# Altium schematic sheet POWER.SchDoc
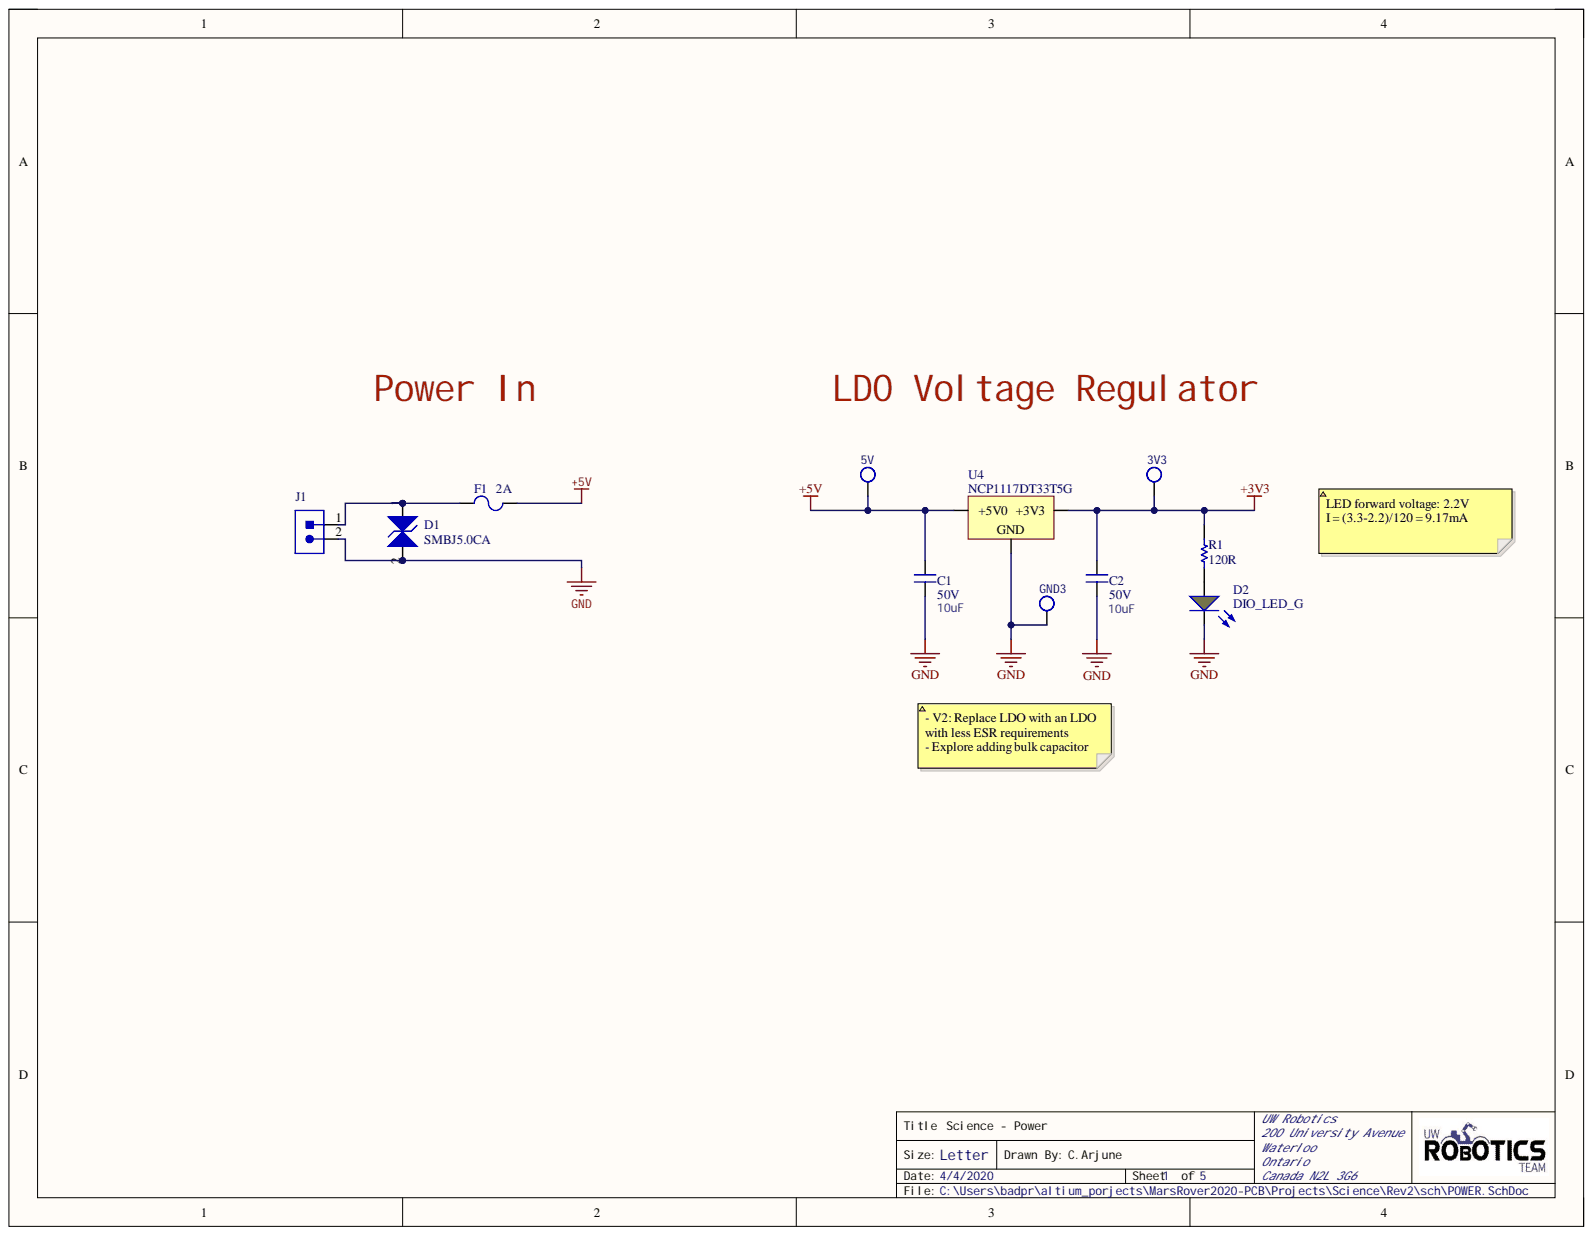
[diagram p0: POWER.SchDoc]

## Parts
3V3  TESTPOINT_LOOP  [TESTPOINT_LOOP]  (value not drawn)
5V  TESTPOINT_LOOP  [TESTPOINT_LOOP]  (value not drawn)
C1  10uF  Voltage Rating: 50V  [CAPC1206(3216)]
C2  10uF  Voltage Rating: 50V  [CAPC1206(3216)]
D1  Manufacturer Part Number 1: SMBJ5.0CA  [TVS_DIODE_BIDIRECTIONAL]  (hidden comment: TVS_DIODE_BIDIRECTIONAL)
D2  DIO_LED_G  [LED_0805_LINE]
F1  Current Rating: 2A  [FUSE_0603]  (hidden comment: FUSE_2A_0603)
GND3  TESTPOINT_LOOP  [TESTPOINT_LOOP]  (value not drawn)
J1  CON_2M_SM4  [JST-B2B-SM4-TB]  (value not drawn)
R1  value 120R  [RESC0603(1608)_N]  (hidden comment: RES_120R_0603)
U4  MPN: NCP1117DT33T5G  [VOLTAGE_REGULATOR]  (hidden comment: 5V0_3V3_LDO)

## Nets
+3V3: 3V3.1 C2.1 R1.2 U4.2
+5V: 5V.1 C1.1 F1.2 U4.3
GND: C1.2 C2.2 D1.2 D2.2 GND3.1 J1.2 U4.1
NetD1_1: D1.1 F1.1 J1.1
NetD2_1: D2.1 R1.1

## Library data of the parts
3V3 5V GND3 = TESTPOINT_LOOP: Loop testpoint through-hole pad; Manufacturer: Keystone Electronics; Manufacturer Part Number 1: 5002; Component Kind: Standard; Supplier 1: Digi-Key; Supplier Part Number 1: 36-5002-ND; Library Name: UWRT_Schematic_Library_2020.SchLib
C1 C2 = CAP_10uF_50V_1206: CAP CER 10UF 50V X5R 1206; Manufacturer: Murata; MPN: GRT31CR61H106KE01L; Component Kind: Standard; Dimensions: 0.126" L x 0.063" W (3.20mm x 1.6mm); Package: 1206 (3216 Metric); Signal Integrity: Cap; Simulation: CAP; Supplier 1: Digi-Key; Supplier Part Number 1: 490-12456-1-ND; Value: 10uF; Library Name: UWRT_Schematic_Library_2020.SchLib
D1 = TVS_DIODE_BIDIRECTIONAL: TVS DIODE 5V 9.2V DO214AA; Manufacturer 1: Littelfuse; Breakdown Voltage: 6.4V; ESD Protection: Yes; Max Breakdown Voltage: 7V; Max Surge Current: 65.3A; Peak Pulse Power: 600W; Clamping Voltage: 9.2V; Component Kind: Standard; ComponentLink1Description: Datasheet; ComponentLink1URL: https://www.littelfuse.com/~/media/electronics/datasheets/tvs_diodes/littelfuse_tvs_diode_smbj_datasheet.pdf.pdf; Composition: Zener; Direction: Bidirectional; Max Operating Temperature: 150°C; Min Breakdown Voltage: 6.4V; Min Operating Temperature: -65°C; Mount: Surface Mount; Package: DO-214AA, SMB; Peak Pulse Current: 65.3A; Polarity: Bidirectional; Power Dissipation: 600W; Reverse Breakdown Voltage: 6.4V; Reverse Standoff Voltage: 5V; Supplier 1: Digi-Key; Supplier Part Number 1: SMBJ5.0CALFCT-ND; Library Name: UWRT_Schematic_Library_2020.SchLib
D2 = DIO_LED_G: LED GREEN DIFFUSED 0805 SMD; Manufacturer: OSRAM; MPN: LG R971-KN-1; Forward Voltage Drop: 2.2V; Component Kind: Standard; Package: 0805 (2012 Metric); Simulation: LED1; Supplier 1: Digi-Key; Supplier Part Number 1: 475-1410-1-ND; Library Name: UWRT_Schematic_Library_2020.SchLib
F1 = FUSE_2A_0603: FUSE SMD 2A 32V; Component Kind: Standard; ComponentLink1Description: https://datasheet.ciiva.com/303/467-303400.pdf?src-supplier=Digi-Key; ComponentLink1URL: https://datasheet.ciiva.com/303/467-303400.pdf?src-supplier=Digi-Key; ComponentLink2Description: http://datasheet.octopart.com/0467002.NRHF-Littelfuse-datasheet-32023482.pdf?src-supplier=Future+Electronics; ComponentLink2URL: http://datasheet.octopart.com/0467002.NRHF-Littelfuse-datasheet-32023482.pdf?src-supplier=Future+Electronics; ComponentLink3Description: http://datasheet.octopart.com/0467002.NRHF-Littelfuse-datasheet-136509808.pdf?src-supplier=Future+Electronics; ComponentLink3URL: http://datasheet.octopart.com/0467002.NRHF-Littelfuse-datasheet-136509808.pdf?src-supplier=Future+Electronics; Mount: Surface Mount; Supplier 1: Digi-Key; Supplier Packaging 1: Cut Tape; Supplier Part Number 1: F7132CT-ND; Voltage Rating (AC): 32V; Voltage Rating (DC): 32V; Library Name: UWRT_Schematic_Library_2020.SchLib
J1 = CON_2M_SM4: CONN HEADER SMD 2POS 2MM; Manufacturer: JST; Manufacturer Part Number: B2B-PH-SM4-TB(LF)(SN); Max Current Rating: 2A; Max Voltage Rating: 100V; Component Kind: Standard; ComponentLink1Description: https://datasheet.ciiva.com/11748/0900766b80ddea81-11748001.pdf?src-supplier=RSComponents; ComponentLink1URL: https://datasheet.ciiva.com/11748/0900766b80ddea81-11748001.pdf?src-supplier=RSComponents; ComponentLink2Description: https://datasheet.ciiva.com/16216/b2b-ph-sm4-tblfsn-16216102.pdf?src-supplier=Future+Electronics; ComponentLink2URL: https://datasheet.ciiva.com/16216/b2b-ph-sm4-tblfsn-16216102.pdf?src-supplier=Future+Electronics; ComponentLink3Description: https://datasheet.ciiva.com/8052/80567-8052023.pdf?src-supplier=Element14; ComponentLink3URL: https://datasheet.ciiva.com/8052/80567-8052023.pdf?src-supplier=Element14; ComponentLink4Description: https://datasheet.ciiva.com/712/eph-712504.pdf?src-supplier=Digi-Key; ComponentLink4URL: https://datasheet.ciiva.com/712/eph-712504.pdf?src-supplier=Digi-Key; ComponentLink5Description: https://datasheet.ciiva.com/712/eph-712505.pdf?src-supplier=Digi-Key; ComponentLink5URL: https://datasheet.ciiva.com/712/eph-712505.pdf?src-supplier=Digi-Key; ComponentLink6Description: https://datasheet.ciiva.com/712/eph-712506.pdf?src-supplier=Digi-Key; ComponentLink6URL: https://datasheet.ciiva.com/712/eph-712506.pdf?src-supplier=Digi-Key; Pitch: 2mm; Supplier 1: Digi-Key; Supplier Part Number 1: 455-1734-1-ND; Library Name: UWRT_Schematic_Library_2020.SchLib
R1 = RES_120R_0603: RES SMD 120 OHM 5% 1/10W 0603; Manufacturer: Yageo; MPN: RC0603JR-07120RL; Component Kind: Standard; Dimensions: 0.063" L x 0.031" W (1.60mm x 0.80mm); Package: 0603 (1608 Metric); Signal Integrity: Res; Simulation: RESISTOR; Supplier 1: Digi-Key; Supplier Part Number 1: 311-120GRCT-ND; Tolerance: 5%; Library Name: UWRT_Schematic_Library_2020.SchLib
U4 = 5V0_3V3_LDO: IC REG LINEAR 3.3V 1A DPAK; Manufacturer: ON Semiconductor; Component Kind: Standard; Current Output: 1A; Supplier 1: Digi-Key; Supplier Part Number 1: NCP1117DT33T5GOSCT-ND; Library Name: UWRT_Schematic_Library_2020.SchLib
